# Altium schematic sheet Sheet1.SchDoc
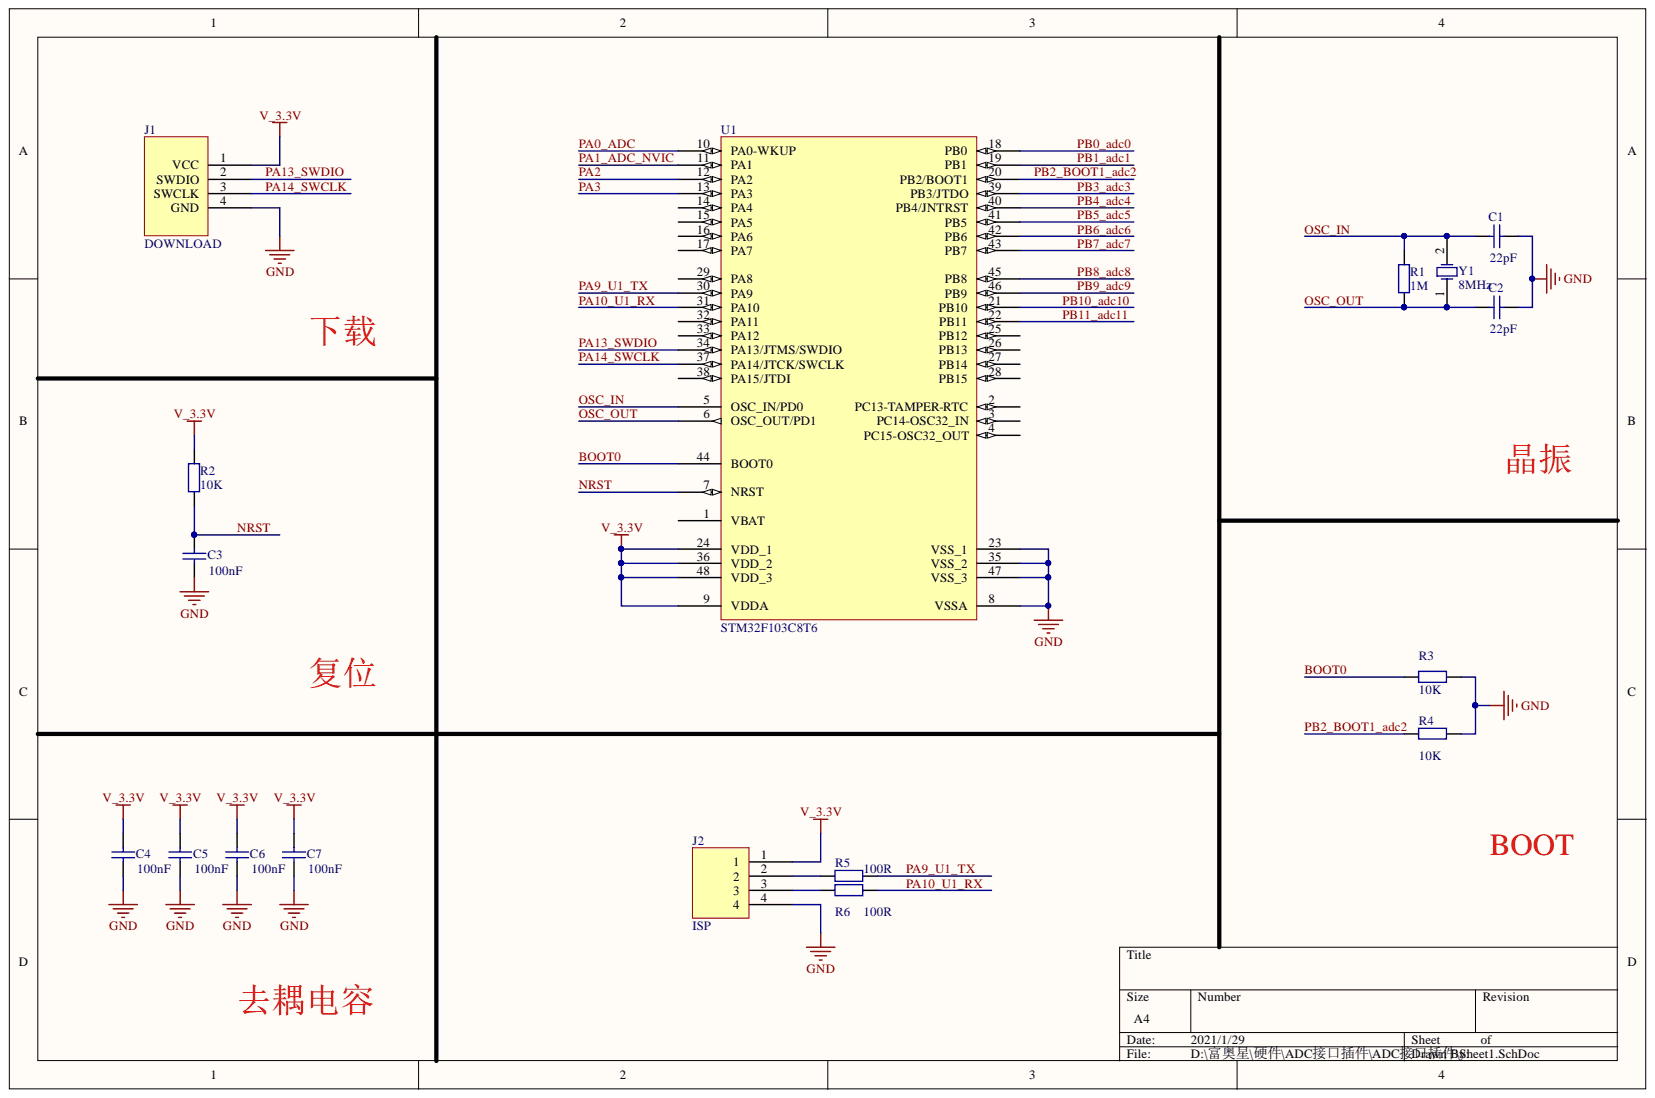
[diagram p0: Sheet1.SchDoc]

## Parts
C1  22pF  [C 0402]
C2  22pF  [C 0402]
C3  100nF  [C 0402]
C4  100nF  [C 0402]
C5  100nF  [C 0402]
C6  100nF  [C 0402]
C7  100nF  [C 0402]
J1  DOWNLOAD  [J4]
J2  ISP  [J4]
R1  1M  [R 0402]
R2  10K  [R 0402]
R3  10K  [R 0402]
R4  10K  [R 0402]
R5  100R  [R 0402]
R6  100R  [R 0402]
U1  STM32F103C8T6  [LQFP48]
Y1  8MHz  [CRYSTAL_3.2*2.5]

## Nets
GND: C1.2 C2.2 C3.2 C4.2 C5.2 C6.2 C7.2 J1.4(GND) J2.4 R3.2 R4.1 U1.8(VSSA) U1.23(VSS_1) U1.35(VSS_2) U1.47(VSS_3)
V_3.3V: C4.1 C5.1 C6.1 C7.1 J1.1(VCC) J2.1 R2.1 U1.9(VDDA) U1.24(VDD_1) U1.36(VDD_2) U1.48(VDD_3)
BOOT0: R3.1 U1.44(BOOT0)
NRST: C3.1 R2.2 U1.7(NRST)
OSC_IN: C1.1 R1.2 U1.5(OSC_IN/PD0) Y1.2
OSC_OUT: C2.1 R1.1 U1.6(OSC_OUT/PD1) Y1.1
PA0_ADC: U1.10(PA0-WKUP)
PA1_ADC_NVIC: U1.11(PA1)
PA2: U1.12(PA2)
PA3: U1.13(PA3)
PA9_U1_TX: R5.1 U1.30(PA9)
PA10_U1_RX: R6.1 U1.31(PA10)
PA13_SWDIO: J1.2(SWDIO) U1.34(PA13/JTMS/SWDIO)
PA14_SWCLK: J1.3(SWCLK) U1.37(PA14/JTCK/SWCLK)
PB0_adc0: U1.18(PB0)
PB1_adc1: U1.19(PB1)
PB2_BOOT1_adc2: R4.2 U1.20(PB2/BOOT1)
PB3_adc3: U1.39(PB3/JTDO)
PB4_adc4: U1.40(PB4/JNTRST)
PB5_adc5: U1.41(PB5)
PB6_adc6: U1.42(PB6)
PB7_adc7: U1.43(PB7)
PB8_adc8: U1.45(PB8)
PB9_adc9: U1.46(PB9)
PB10_adc10: U1.21(PB10)
PB11_adc11: U1.22(PB11)
NetJ2_2: J2.2 R5.2
NetJ2_3: J2.3 R6.2

Not connected: U1 1(VBAT) 2(PC13-TAMPER-RTC) 3(PC14-OSC32_IN) 4(PC15-OSC32_OUT) 14(PA4) 15(PA5) 16(PA6) 17(PA7) 25(PB12) 26(PB13) 27(PB14) 28(PB15) 29(PA8) 32(PA11) 33(PA12) 38(PA15/JTDI)

## Library data of the parts
C1-C7 = Cap: Capacitor; Component Kind: Standard; LatestRevisionDate: 17-Jul-2002; LatestRevisionNote: Re-released for DXP Platform.; Library Name: Miscellaneous Devices.IntLib; PackageDescription: Radial Cap, Thru-Hole; 2 Leads; 0.3 in Pin Spacing; PackageReference: RAD-0.3; Published: 8-Jun-2000; Simulation: CAP; Publisher: Altium Limited
  C1 C2: Value: 22pF
  C3-C7: Value: 100nF
J1 = 下载: Component Kind: Standard; Library Name: Schlib1.SchLib
J2 = J4: Component Kind: Standard; Library Name: Schlib1.SchLib
R1-R6 = Res2: Resistor; Component Kind: Standard; LatestRevisionDate: 17-Jul-2002; LatestRevisionNote: Re-released for DXP Platform.; Library Name: Miscellaneous Devices.IntLib; PackageDescription: Axial Device, Thru-Hole; 2 Leads; 0.4 in Pin Spacing; PackageReference: AXIAL-0.4; Published: 8-Jun-2000; Simulation: RESISTOR; Publisher: Altium Limited
  R1: Value: 1M
  R2-R4: Value: 10K
  R5 R6: Value: 100R
U1 = STM32F103C8T6: Flaah:64/RAM:20/IO:36; BOM名称: 32位STM单片机; 版权归属: 源创客; Component Kind: Standard; Library Name: Schlib1.SchLib; 学习资源: www.ooocke.com
Y1 = XTAL: Crystal Oscillator; Component Kind: Standard; LatestRevisionDate: 17-Jul-2002; LatestRevisionNote: Re-released for DXP Platform.; Library Name: Miscellaneous Devices.IntLib; PackageDescription: Crystal, Thru-Hole; 2 Leads; Body 3.1 x 8.2 mm (Dia.xH); PackageReference: R38; Published: 8-Jun-2000; Simulation: XTAL; Publisher: Altium Limited
